# Altium schematic sheet MCU_oscilliation.SchDoc
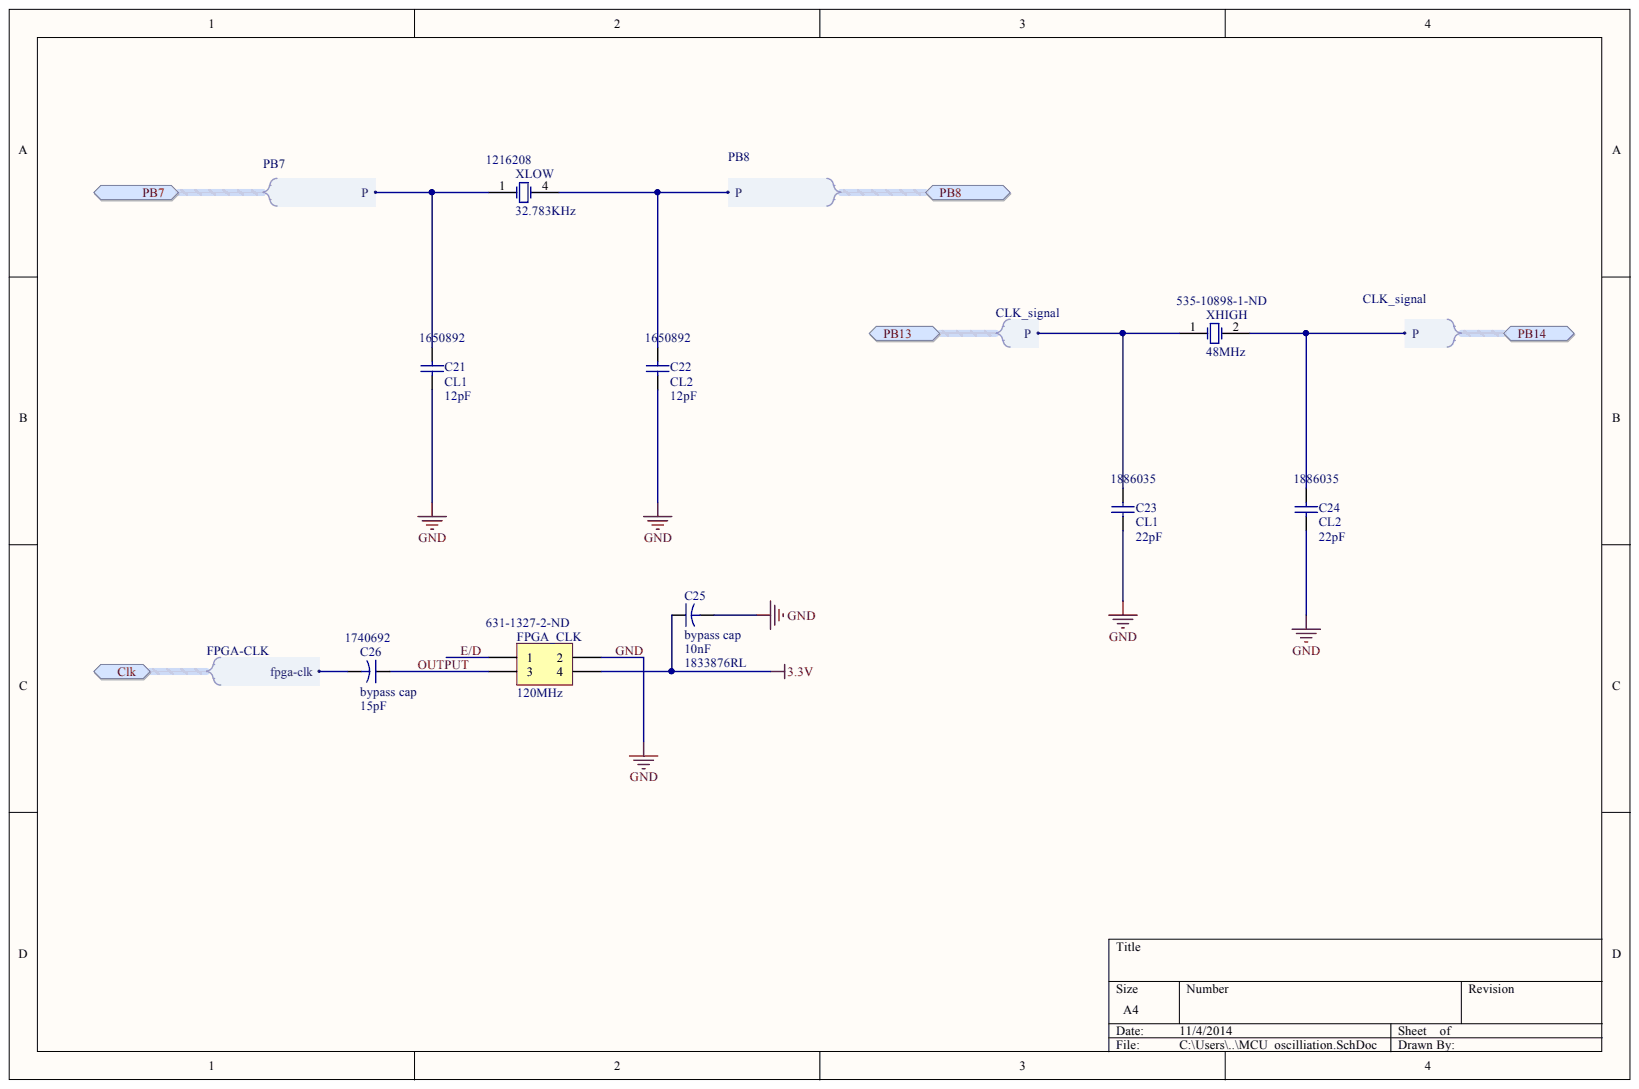
[diagram p0: MCU_oscilliation.SchDoc]

## Parts
C21  CL1  value 12pF  Supplier Part Number 1: 1650892  [C1206]
C22  CL2  value 12pF  Supplier Part Number 1: 1650892  [C1206]
C23  CL1  value 22pF  Supplier Part Number 1: 1886035  [C1206]
C24  CL2  value 22pF  Supplier Part Number 1: 1886035  [C1206]
C25  bypass cap  value 10nF  [C1206]
C26  bypass cap  value 15pF  Supplier Part Number 1: 1740692  [C1206]
FPGA_CLK  120MHz  Supplier Part Number 1: 631-1327-2-ND  [OSCI-4FPGA]
XHIGH  48MHz  Supplier Part Number 1: 535-10898-1-ND  [XTAL-85]
XLOW  32.783KHz  Supplier Part Number 1: 1216208  [MC-306]

## Ports
CLK, CLK.FPGA-CLK, PB7, PB7.P, PB8, PB8.P, PB13, PB13.P, PB14, PB14.P

## Nets
3.3V: C25.1 FPGA_CLK.4
E/D: FPGA_CLK.1
GND: C21.1 C22.1 C23.1 C24.1 C25.2 FPGA_CLK.2
OUTPUT: C26.1 FPGA_CLK.3
PB7: C21.2 XLOW.1 ; port PB7
PB8: C22.2 XLOW.4 ; port PB8
PB13: C23.2 XHIGH.1 ; port PB13
PB14: C24.2 XHIGH.2 ; port PB14
NetC26_2: C26.2 ; port CLK

## Library data of the parts
C21 C22 = Cap Semi: Capacitor (Semiconductor SIM Model); Manufacturer: KEMET; Manufacturer Part Number: C1206C120J5GACTU; Capacitance: 12; Capacitance Tolerance: ± 5%; Capacitor Case Style: 1206 [3216 Metric]; Capacitor Terminals: SMD; Dielectric Characteristic: C0G / NP0; Product Range: KEMET - C Series; SVHC: No SVHC (16-Dec-2013); Temperature Coefficient: 0ppm/°C ± 30; Voltage Rating: 50; Capacitance Tolerance ±: ± 5%; Ceramic Capacitor Case: 1206 [3216 Metric]; Component Kind: Standard; ComponentLink1Description: http://www.farnell.com/datasheets/1732697.pdf; ComponentLink1URL: http://www.farnell.com/datasheets/1732697.pdf; LatestRevisionDate: 29-May-2009; LatestRevisionNote: IPC-7351 Footprint Added.; Library Name: Miscellaneous Devices.IntLib; MSL: MSL 1 - Unlimited; Operating Temperature Max: 125; Operating Temperature Min: -55; Operating Temperature Range: -55°C to +125; PackageDescription: Chip Capacitor; PackageReference: C1206; Packaging: Cut Tape; Pricing 1: 1=1.12, 25=0.95, 50=0.52, 100=0.34, 500=0.29 (EUR); Published: 8-Jun-2000; RoHS: YES; Signal Integrity: Cap Semi; Simulation: CAP; Stock 1: 13114; Supplier 1: Farnell; Publisher: Altium Limited
C23 C24 = Cap Semi: Capacitor (Semiconductor SIM Model); Manufacturer: JOHANSON DIELECTRICS; Manufacturer Part Number: 102R18N220JV4E; Component Kind: Standard; ComponentLink1Description: http://www.johansondielectrics.com/product-catalog.html; ComponentLink1URL: http://www.johansondielectrics.com/product-catalog.html; ComponentLink2Description: http://www.johansondielectrics.com/images/stories/catalog/JDI_Full-Catalog_2012-01.pdf; ComponentLink2URL: http://www.johansondielectrics.com/images/stories/catalog/JDI_Full-Catalog_2012-01.pdf; LatestRevisionDate: 29-May-2009; LatestRevisionNote: IPC-7351 Footprint Added.; Library Name: Miscellaneous Devices.IntLib; PackageDescription: Chip Capacitor; PackageReference: C1206; Pricing 1: 50=1.3, 250=0.859, 500=0.626, 1000=0.509, 3000=0.478 (EUR); Published: 8-Jun-2000; RoHS: YES; Signal Integrity: Cap Semi; Simulation: CAP; Stock 1: 3550; Supplier 1: Farnell; Publisher: Altium Limited
C25 C26 = Cap2: Capacitor; Manufacturer: AVX; Manufacturer Part Number: 06031C103JAT2A; Capacitance: 0.01; Capacitance Tolerance: ± 5%; Ceramic Capacitor Case: 0603 ^1608 Metric]; Dielectric Characteristic: X7; Voltage Rating: 100; Component Kind: Standard; ComponentLink1Description: http://www.farnell.com/datasheets/84526.pdf; ComponentLink1URL: http://www.farnell.com/datasheets/84526.pdf; Library Name: Miscellaneous Devices.IntLib; PackageDescription: Capacitor; 2 Leads; PackageReference: CAPR5-4X5; Packaging: Cut Tape; Product Range: -; Published: 23-Sep-2002; RoHS: YES; Signal Integrity: Cap; Simulation: CAP; Supplier 1: Farnell; Publisher: Altium Limited
  C25: Supplier Part Number 1: 1833876RL
FPGA_CLK = Header 2X2: Header, 2-Pin, Dual row; Manufacturer: Fox Electronics; Manufacturer Part Number: FXO-HC736R-120; Current - Supply (Max): 47mA; Frequency Stability: ±25ppm; Function: Tri-State (Output Enable); Output: HCMOS; Voltage - Supply: 3.3V; Category: Crystals and Oscillators; Component Kind: Standard; ComponentLink1Description: http://www.foxonline.com/pdfs/FXO_HC73.pdf; ComponentLink1URL: http://www.foxonline.com/pdfs/FXO_HC73.pdf; Current - Supply (Disable) (Max): -; Frequency: 120MHz; Height: 0.055" (1.40mm); LatestRevisionDate: 17-Jul-2002; LatestRevisionNote: Re-released for DXP Platform.; Library Name: Miscellaneous Connectors.IntLib; Mounting Type: Surface Mount; Operating Temperature: -40°C ~ 85°C; Package / Case: 4-SMD, No Lead (DFN, LCC); Packaging: Tape & Reel (TR); Pricing 1: 1000=1.42097, 3000=1.32297, 5000=1.27398, 10000=1.22498 (EUR); Ratings: -; RoHS: RoHS Compliant; Signal Integrity: Connector; Size / Dimension: 0.295" L x 0.205" W (7.50mm x 5.20mm); Stock 1: 200; Supplier 1: Digi-Key; Type: XO (Standard); Publisher: Altium Limited
XHIGH = XTAL: Crystal Oscillator; Manufacturer: Abracon Corporation; Manufacturer Part Number: ABM2-48.000MHZ-D4YF-T; ESR (Equivalent Series Resistance): 70 Ohm; Frequency Stability: ±30ppm; Load Capacitance: 18pF; Operating Mode: 3rd Overtone; Category: Crystals and Oscillators; Component Kind: Standard; ComponentLink1Description: http://www.abracon.com/Resonators/abm2.pdf; ComponentLink1URL: http://www.abracon.com/Resonators/abm2.pdf; Frequency: 48MHz; Frequency Tolerance: ±30ppm; Height: 0.063" (1.60mm); LatestRevisionDate: 17-Jul-2002; LatestRevisionNote: Re-released for DXP Platform.; Library Name: Miscellaneous Devices.IntLib; Mounting Type: Surface Mount; Operating Temperature: -40°C ~ 85°C; Package / Case: 2-SMD; PackageDescription: Crystal, Thru-Hole; 2 Leads; Body 3.1 x 8.2 mm (Dia.xH); PackageReference: R38; Packaging: Cut Tape (CT); Pricing 1: 1=0.62, 10=0.525, 50=0.4708, 100=0.4189, 500=0.39894 (EUR); Published: 8-Jun-2000; Ratings: -; RoHS: RoHS Compliant; Simulation: XTAL; Size / Dimension: 0.315" L x 0.177" W (8.00mm x 4.50mm); Stock 1: 1458; Supplier 1: Digi-Key; Type: MHz Crystal; Publisher: Altium Limited
XLOW = XTAL: Crystal Oscillator; Manufacturer: EPSON; Manufacturer Part Number: Q13MC30610003; Crystal Case Type: 8mm x 3.8; Crystal Mounting Type: SMD; Frequency: 32.768; Frequency Tolerance: ± 20; Load Capacitance: 12.5; No. of Pins: 4; SVHC: No SVHC (16-Jun-2014); Component Kind: Standard; ComponentLink1Description: http://www.epsondevice.com/docs/qd/en/DownloadServlet?id=ID000663; ComponentLink1URL: http://www.epsondevice.com/docs/qd/en/DownloadServlet?id=ID000663; Frequency Stability: -; LatestRevisionDate: 17-Jul-2002; LatestRevisionNote: Re-released for DXP Platform.; Library Name: Miscellaneous Devices.IntLib; Operating Temperature Max: 85; Operating Temperature Min: -40; PackageDescription: Crystal, Thru-Hole; 2 Leads; Body 3.1 x 8.2 mm (Dia.xH); PackageReference: R38; Packaging: Cut Tape<!--FANDB--><div class="features-and-benefits-container"></div>; Pricing 1: 1=9.75, 10=7.95, 100=4.85, 250=4.1, 900=3.65, 3000=3.3, 3000=3, 20000=2.8 (EUR); Published: 8-Jun-2000; RoHS: YES; Simulation: XTAL; Stock 1: 7540; Supplier 1: Farnell; Publisher: Altium Limited
